# Altium schematic sheet 24V Multiphase Buck.SchDoc
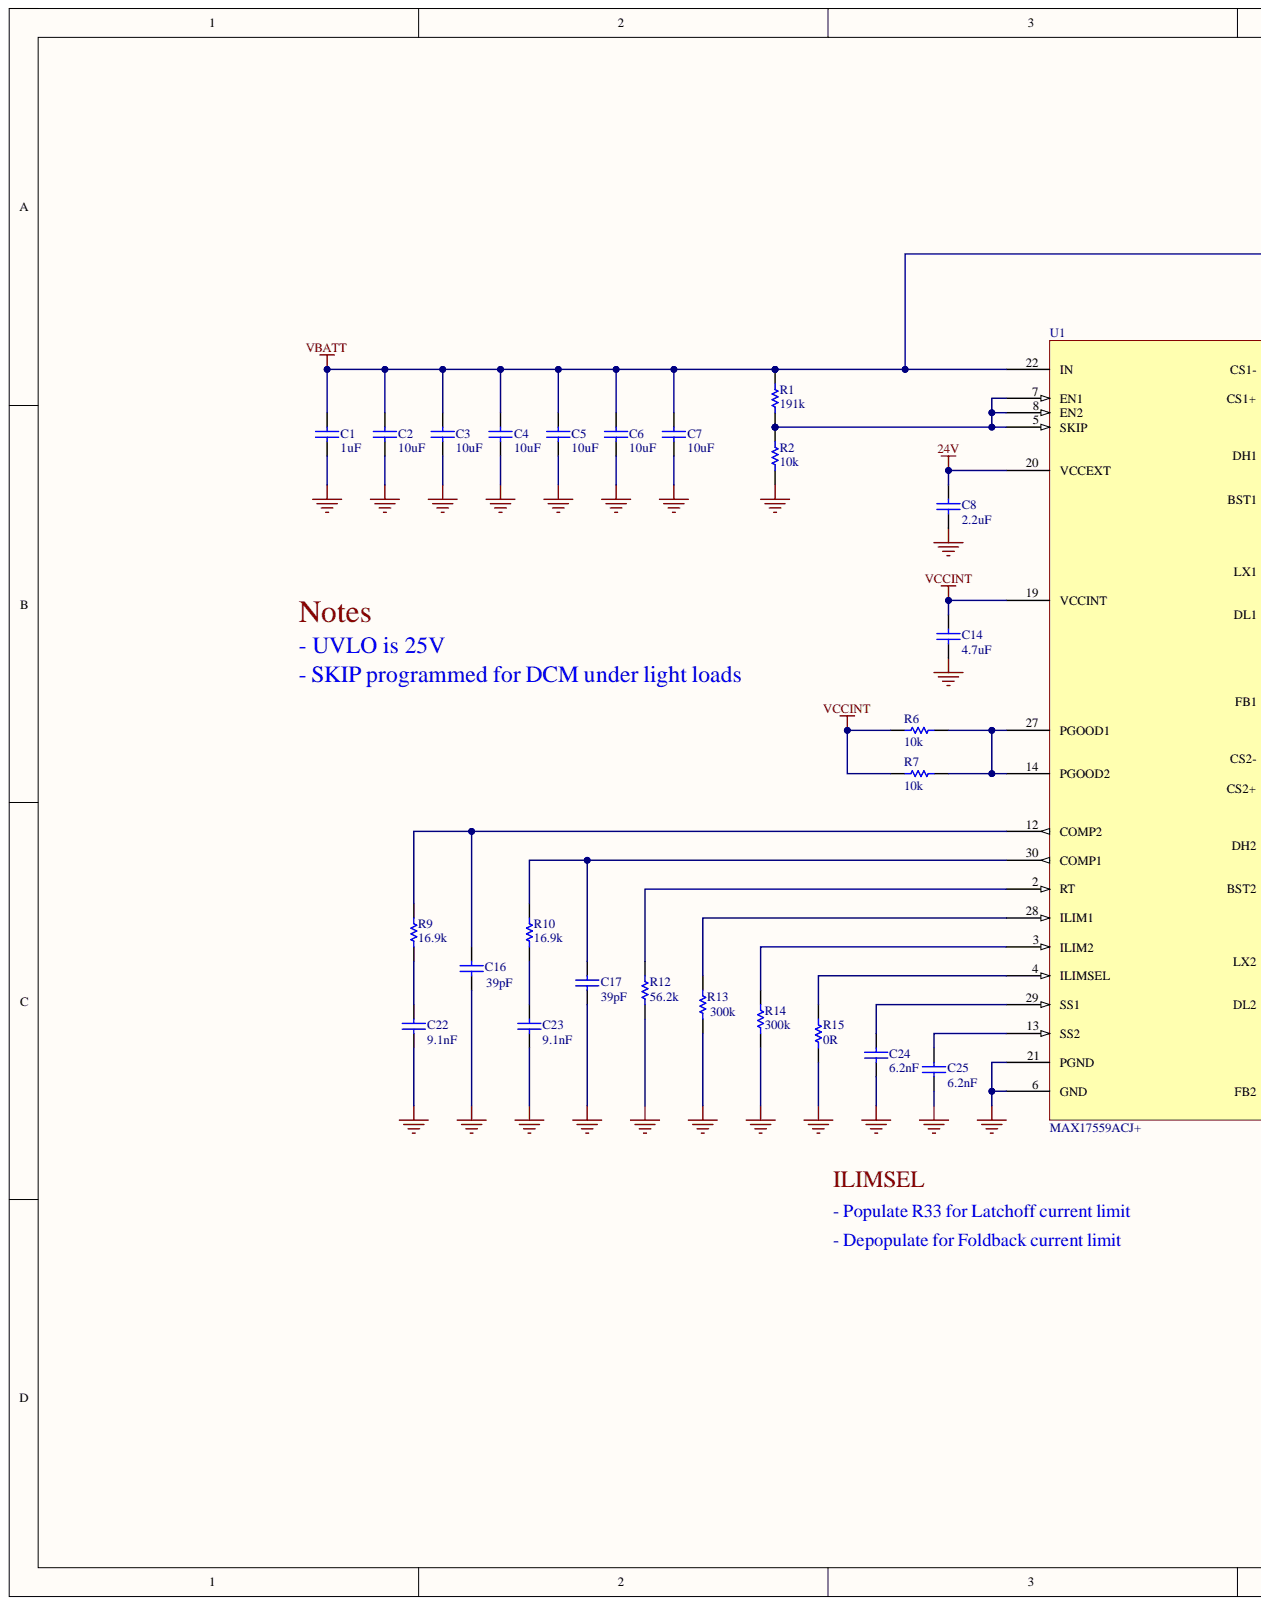
[diagram p0: 24V Multiphase Buck.SchDoc, left]
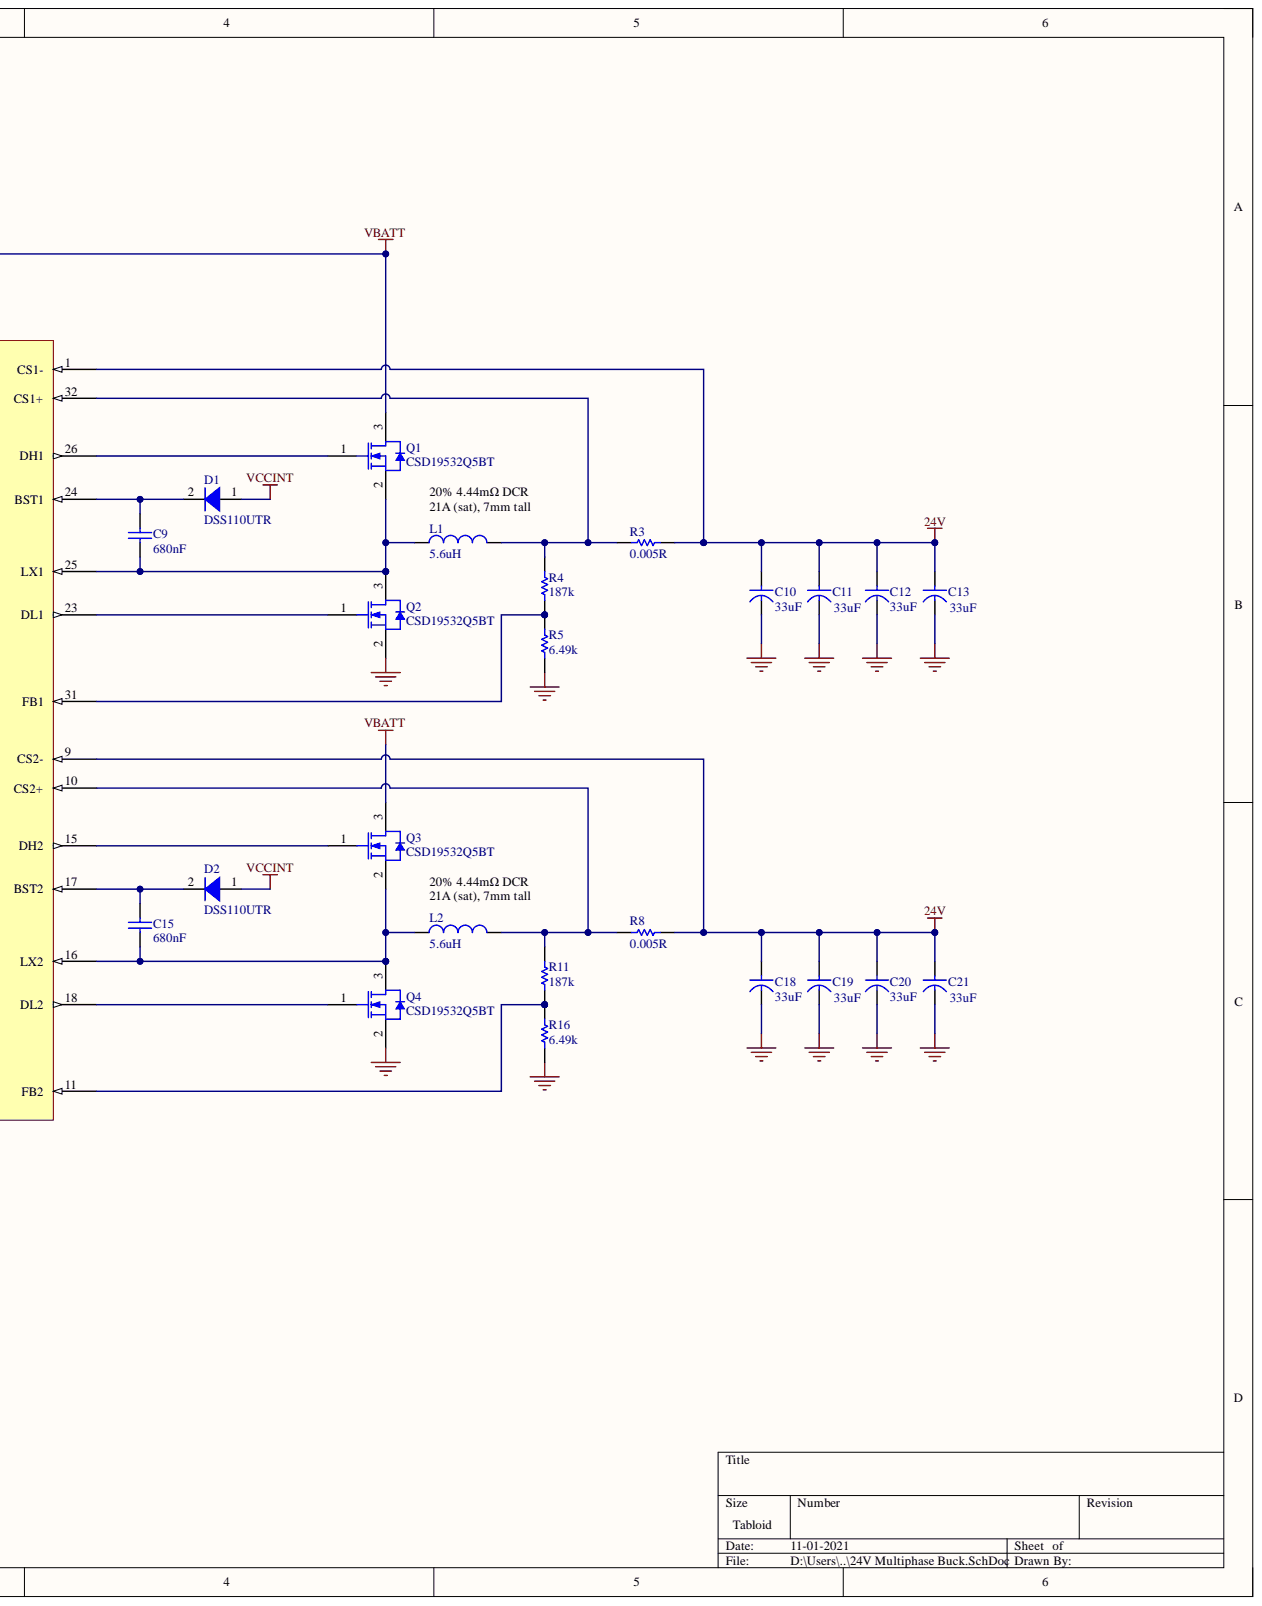
[diagram p1: 24V Multiphase Buck.SchDoc, right]

## Parts
C1  1uF  [C1206]
C2  10uF  [C2220]
C3  10uF  [C2220]
C4  10uF  [C2220]
C5  10uF  [C2220]
C6  10uF  [C2220]
C7  10uF  [C2220]
C8  2.2uF  [C0603]
C9  680nF  [C1206]
C10  33uF  [CAPAE9983X105M]
C11  33uF  [CAPAE9983X105M]
C12  33uF  [CAPAE9983X105M]
C13  33uF  [CAPAE9983X105M]
C14  4.7uF  [C0805]
C15  680nF  [C1206]
C16  39pF  [C0805]
C17  39pF  [C0805]
C18  33uF  [CAPAE9983X105M]
C19  33uF  [CAPAE9983X105M]
C20  33uF  [CAPAE9983X105M]
C21  33uF  [CAPAE9983X105M]
C22  9.1nF  [C0603]
C23  9.1nF  [C0603]
C24  6.2nF  [C0603]
C25  6.2nF  [C0603]
D1  DSS110UTR  [SODFL360X110-2N]
D2  DSS110UTR  [SODFL360X110-2N]
L1  5.6uH  Height: 7mm  Inductance Tolerance: 20%  Max DC Current: 21A  [IHLP6767GZER5R6M11]
L2  5.6uH  Height: 7mm  Inductance Tolerance: 20%  Max DC Current: 21A  [IHLP6767GZER5R6M11]
Q1  CSD19532Q5BT  [CSD19532Q5BT]
Q2  CSD19532Q5BT  [CSD19532Q5BT]
Q3  CSD19532Q5BT  [CSD19532Q5BT]
Q4  CSD19532Q5BT  [CSD19532Q5BT]
R1  191k  [RESC1005X04N]
R2  10k  [RESC1005X04N]
R3  0.005R
R4  187k  [RESC1005X04N]
R5  6.49k  [RESC1005X04N]
R6  10k  [RESC1005X04N]
R7  10k  [RESC1005X04N]
R8  0.005R
R9  16.9k  [R0805]
R10  16.9k  [R0805]
R11  187k  [RESC1005X04N]
R12  56.2k  [RESC1005X04N]
R13  300k  [RESC1005X04N]
R14  300k  [RESC1005X04N]
R15  0R  [RESC1005X04N]
R16  6.49k  [RESC1005X04N]
U1  MAX17559ACJ+

## Nets
24V: C8.2 C10.1 C11.1 C12.1 C13.1 C18.1 C19.1 C20.1 C21.1 R3.2 R8.2 U1.1(CS1-) U1.9(CS2-) U1.20(VCCEXT)
GND: C1.1 C2.1 C3.1 C4.1 C5.1 C6.1 C7.1 C8.1 C10.2 C11.2 C12.2 C13.2 C14.1 C16.1 C17.1 C18.2 C19.2 C20.2 C21.2 C22.1 C23.1 C24.1 C25.1 Q2.2 Q4.2 R2.1 R5.1 R12.1 R13.1 R14.1 R15.1 R16.1 U1.6(GND) U1.21(PGND)
VBATT: C1.2 C2.2 C3.2 C4.2 C5.2 C6.2 C7.2 Q1.3 Q3.3 R1.2 U1.22(IN)
VCCINT: C14.2 D1.1 D2.1 R6.1 R7.1 U1.19(VCCINT)
NetC9_1: C9.1 L1.1 Q1.2 Q2.3 U1.25(LX1)
NetC9_2: C9.2 D1.2 U1.24(BST1)
NetC15_1: C15.1 L2.1 Q3.2 Q4.3 U1.16(LX2)
NetC15_2: C15.2 D2.2 U1.17(BST2)
NetC16_2: C16.2 R9.2 U1.12(COMP2)
NetC17_2: C17.2 R10.2 U1.30(COMP1)
NetC22_2: C22.2 R9.1
NetC23_2: C23.2 R10.1
NetC24_2: C24.2 U1.29(SS1)
NetC25_2: C25.2 U1.13(SS2)
NetL1_2: L1.2 R3.1 R4.2 U1.32(CS1+)
NetL2_2: L2.2 R8.1 R11.2 U1.10(CS2+)
NetQ1_1: Q1.1 U1.26(DH1)
NetQ2_1: Q2.1 U1.23(DL1)
NetQ3_1: Q3.1 U1.15(DH2)
NetQ4_1: Q4.1 U1.18(DL2)
NetR1_1: R1.1 R2.2 U1.5(SKIP) U1.7(EN1) U1.8(EN2)
NetR4_1: R4.1 R5.2 U1.31(FB1)
NetR6_2: R6.2 R7.2 U1.14(PGOOD2) U1.27(PGOOD1)
NetR11_1: R11.1 R16.2 U1.11(FB2)
NetR12_2: R12.2 U1.2(RT)
NetR13_2: R13.2 U1.28(ILIM1)
NetR14_2: R14.2 U1.3(ILIM2)
NetR15_2: R15.2 U1.4(ILIMSEL)

## Library data of the parts
C1 = CL31B105KCHNFNE: Cap Ceramic 1uF 100V X7R 10% SMD 1206 125°C Embossed Plastic T/R; Manufacturer: Samsung; Database Table Name: Capacitor; Capacitance: 1uF; Case Code (Imperial): 1206; Case Code (Metric): 3216; Case/Package: 1206; Category: Ceramic Capacitors; Component Kind: Standard; ComponentLink1URL: https://datasheet.ciiva.com/25793/cl31b105kchnfne-25793297.pdf?src-supplier=Digi-Key; Length: 0.126inch; Manufacturer Part Number: CL31B105KCHNFNE; Max Operating Temperature: 125°C; Min Operating Temperature: -55°C; Mount: Surface Mount; Package Quantity: 1; Packaging: Cut Tape; Part Number: CL31B105KCHNFNE; Pricing 1: 1=0.27000, 10=0.18500, 50=0.12360, 100=0.10510, 500=0.07418, 1000=0.06492 (USD); RoHS: Yes; RoHS Compliant: Yes; Stock 1: 65421; Supplier 1: Digi-Key; Supplier Part Number 1: 1276-3094-1-ND; Thickness: 0.071inch; Tolerance: 10%; Voltage Rating: 100V; Width: 0.063inch; Library Name: EMRLibrary.DBLib
C2-C7 = 22201C106MAT2A: Cap Ceramic 10uF 100V X7R 20% SMD 2220 125°C Embossed T/R; Manufacturer: Kyocera AVX; Database Table Name: Capacitor; Capacitance: 10uF; Case Code (Imperial): 2220; Case Code (Metric): 5750; Case/Package: 2220; Category: Ceramic Capacitors; Component Kind: Standard; Dielectric: X7R; Length: 0.224inch; Manufacturer Part Number: 22201C106MAT2A; Max Operating Temperature: 125°C; Min Operating Temperature: -55°C; Mount: Surface Mount; Packaging: Tape and Reel; Part Number: 22201C106MAT2A; Pricing 1: 1=2.06000, 10=1.66400, 50=1.45200, 100=1.27050 (USD); RoHS: Yes; Stock 1: 8969; Supplier 1: Digi-Key; Supplier Part Number 1: 478-9622-1-ND; Thickness: 0.11inch; Tolerance: 20%; Voltage Rating: 100V; Voltage Rating (DC): 100V; Width: 0.197inch; Library Name: EMRLibrary.DBLib
C8 = GRT188R61H225KE13D: 0603 2.2 uF 50 V ±10 % Tolerance X5R SMT Multilayer Ceramic Capacitor; Manufacturer: Murata; Tolerance: 10%; Voltage Rating: 50V; Capacitance: 2.2uF; Case Code (Imperial): 0603; Case Code (Metric): 1608; Case/Package: 0603; Category: Ceramic Capacitors; Component Kind: Standard; Database Table Name: Capacitor; Dielectric: X5R; Manufacturer Part Number: GRT188R61H225KE13D; Max Operating Temperature: 85°C; Min Operating Temperature: -55°C; Mount: Surface Mount; Packaging: Tape and Reel; Part Number: GRT188R61H225KE13D; Pricing 1: 1=0.33000, 10=0.22900, 50=0.16000, 100=0.13720, 500=0.10292, 1000=0.08690 (USD); RoHS: Yes; Stock 1: 38519; Supplier 1: Digi-Key; Supplier Part Number 1: 490-12332-1-ND; Library Name: EMRLibrary.DBLib
C9 C15 = C1206C684K1RAC7800: Cap Cer 0.68UF 100V X7R 1206; Manufacturer: KEMET; Database Table Name: Capacitor; Capacitance: 680nF; Case/Package: 1206; Category: Ceramic Capacitors; Component Kind: Standard; Manufacturer Part Number: C1206C684K1RAC7800; Max Operating Temperature: 100°C; Min Operating Temperature: 0°C; Mount: Surface Mount; Part Number: C1206C684K1RAC7800; Pricing 1: 1=0.38000, 10=0.26000, 50=0.18240, 100=0.15630, 500=0.11722, 1000=0.09898 (USD); RoHS: No; Stock 1: 7801; Supplier 1: Digi-Key; Supplier Part Number 1: 399-15137-1-ND; Library Name: EMRLibrary.DBLib
C10-C13 C18-C21 = EEV-FC1H330P: Cap 33UF 50V Elect Fc SMD; Manufacturer: Panasonic; Database Table Name: Capacitor; Ripple Current: 225mA; Tolerance: 20%; Capacitance: 33uF; Case/Package: Radial; Category: Aluminum Electrolytic Capacitors; Component Kind: Standard; Manufacturer Part Number: EEV-FC1H330P; Max Operating Temperature: 105°C; Min Operating Temperature: -40°C; Mount: Surface Mount; Package Quantity: 500; Packaging: Tape and Reel; Part Number: EEV-FC1H330P; Pricing 1: 1=0.69000, 10=0.42200, 50=0.30300, 100=0.26200 (USD); RoHS: No; Stock 1: 2130; Supplier 1: Digi-Key; Supplier Part Number 1: PCE3258CT-ND; Termination: SMD/SMT; Voltage Rating: 50V; Library Name: EMRLibrary.DBLib
C14 = CL21A475KOFNNNG: 4.7µF Ceramic Capacitor 16V % Samsung Electro-Mechanics CL21A475KOFNNNG; Dielectric: X5R; Tolerance: 10%; Capacitance: 4.7uF; Case Code (Imperial): 0805; Case Code (Metric): 2012; Case/Package: 0805; Component Kind: Standard; Database Table Name: Capacitor; Depth: 1.25mm; Height: 1.25mm; Length: 2mm; Manufacturer: Samsung; Manufacturer Part Number: CL21A475KOFNNNG; Max Operating Temperature: 85°C; Min Operating Temperature: -55°C; Packaging: Tape and Reel; Part Number: CL21A475KOFNNNG; Pricing 1: 1=0.12, 10=0.084, 100=0.0394, 500=0.0276, 1000=0.0229 (USD); Radiation Hardening: No; Stock 1: 16459; Supplier 1: Digi-Key; Supplier Part Number 1: 1276-6463-6-ND; Thickness: 0.053inch; Voltage Rating: 16V; Width: 0.049inch; Library Name: EMRLibrary.DBLib
C16 C17 = CL21C390JBANNNC: Ceramic capacitor SMD-0805 39pF /50V NP0# J +-5% Samsung , RoHS; Capacitance: 39pF; Case Code (Imperial): 0805; Case Code (Metric): 2012; Case/Package: 0805; Category: Ceramic Capacitors; Component Kind: Standard; Database Table Name: Capacitor; Manufacturer: Samsung; Manufacturer Part Number: CL21C390JBANNNC; Mount: Surface Mount; Part Number: CL21C390JBANNNC; Pricing 1: 1=0.10000, 10=0.05100, 50=0.02780, 100=0.02270, 500=0.01622, 1000=0.01274 (USD); RoHS: Yes; Stock 1: 206197; Supplier 1: Digi-Key; Supplier Part Number 1: 1276-1769-1-ND; Library Name: EMRLibrary.DBLib
C22 C23 = C0603C912J3GACTU: Cap Ceramic 0.0091uF 25V C0G 5% Pad SMD 0603 125°C Extreme Low ESR T/R; Manufacturer: KEMET; Database Table Name: Capacitor; Capacitance: 9.1nF; Case Code (Imperial): 0603; Case Code (Metric): 1608; Case/Package: 0603; Category: Ceramic Capacitors; Component Kind: Standard; Dielectric: C0G; Dielectric Material: Ceramic; Manufacturer Part Number: C0603C912J3GACTU; Max Operating Temperature: 125°C; Min Operating Temperature: -55°C; Mount: Surface Mount; Number of Pins: 2; Package Quantity: 1; Packaging: Tape and Reel; Part Number: C0603C912J3GACTU; Pricing 1: 1=0.54000, 10=0.37300, 50=0.26120, 100=0.22390, 500=0.16792, 1000=0.14181 (USD); RoHS: Yes; Stock 1: 17785; Supplier 1: Digi-Key; Supplier Part Number 1: 399-9982-1-ND; Termination: SMD/SMT; Tolerance: 5%; Voltage Rating: 25V; Voltage Rating (DC): 25V; Library Name: EMRLibrary.DBLib
C24 C25 = 06031C622JAT2A: Cap MLCC SMD 0603 100V X7R 6200pF ±5% Commercial Ti/Sn Plated 7T/R"; Manufacturer: Kyocera AVX; Database Table Name: Capacitor; Capacitance: 6.2nF; Case/Package: 0603; Category: Ceramic Capacitors; Component Kind: Standard; Manufacturer Part Number: 06031C622JAT2A; Mount: Surface Mount; Part Number: 06031C622JAT2A; Pricing 1: 1=0.27000, 10=0.18600, 50=0.12420, 100=0.10560, 500=0.07452, 1000=0.06520 (USD); RoHS: Yes; Stock 1: 53066; Supplier 1: Digi-Key; Supplier Part Number 1: 478-10228-1-ND; Library Name: EMRLibrary.DBLib
D1 D2 = DSS110UTR: Schottky 100V SOD-123FL; Manufacturer: SMC; Database Table Name: Diode; Category: Industrial Control; Component Kind: Standard; Manufacturer Part Number: DSS110UTR; Mount: Surface Mount; Packaging: Tape and Reel; Part Number: DSS110UTR; Pricing 1: 3000=0.03080, 9000=0.02570, 15000=0.02550, 60000=0.02480, 90000=0.02460 (USD); RoHS: No; Supplier 1: Future Electronics; Supplier Part Number 1: 6125592; Library Name: EMRLibrary.DBLib
L1 L2 = IHLP6767GZER5R6M11: Ind High Current Shielded Wirewound 5.6uH 20% 100KHz 28A 6767 T/R; Manufacturer: Vishay Dale; Core Material: Metal,Composite; Database Table Name: Inductor; DC Resistance (DCR): 4.44mR; Self Resonant Frequency: 11.8MHz; Case Code (Imperial): 1210; Case Code (Metric): 3225; Case/Package: 6767; Category: Fixed Inductors; Component Kind: Standard; Component Type: Inductor; ComponentLink1Description: https://datasheet.ciiva.com/1052/lp67gz11-1052676.pdf?src-supplier=Digi-Key; ComponentLink1URL: https://datasheet.ciiva.com/1052/lp67gz11-1052676.pdf?src-supplier=Digi-Key; ComponentLink2Description: https://datasheet.ciiva.com/1052/lp67gz11-1052677.pdf?src-supplier=Digi-Key; ComponentLink2URL: https://datasheet.ciiva.com/1052/lp67gz11-1052677.pdf?src-supplier=Digi-Key; ComponentLink3Description: https://datasheet.ciiva.com/1052/lp67gz11-1052678.pdf?src-supplier=Digi-Key; ComponentLink3URL: https://datasheet.ciiva.com/1052/lp67gz11-1052678.pdf?src-supplier=Digi-Key; ComponentLink4Description: https://datasheet.ciiva.com/8496/lp67gz11-8496089.pdf?src-supplier=Avnet; ComponentLink4URL: https://datasheet.ciiva.com/8496/lp67gz11-8496089.pdf?src-supplier=Avnet; ComponentLink5Description: https://datasheet.ciiva.com/8017/1852632-8017494.pdf?src-supplier=Element14; ComponentLink5URL: https://datasheet.ciiva.com/8017/1852632-8017494.pdf?src-supplier=Element14; ComponentLink6Description: https://datasheet.ciiva.com/17269/lp67gz11-17269071.pdf?src-supplier=Avnet+Europe; ComponentLink6URL: https://datasheet.ciiva.com/17269/lp67gz11-17269071.pdf?src-supplier=Avnet+Europe; Composition: Wirewound; Contact Plating: Tin; Current Rating: 28A; Depth: 17.15mm; Height - Seated (Max): 0.276inch; Inductance: 5.6uH; Lead Free: Lead Free; Length: 17.28mm; Manufacturer Part Number: IHLP6767GZER5R6M11; Max Operating Temperature: 125°C; Military Standard: Not; Min Operating Temperature: -55°C; Mount: Surface Mount; Number of Pins: 2; Package Quantity: 200; Packaging: Tape and Reel; Part Number: IHLP6767GZER5R6M11; Pricing 1: 1=4.62000, 10=4.23500, 25=3.85000, 50=3.23400, 100=2.77200 (USD); Radiation Hardening: No; REACH SVHC: Unknown; RMS Current (Irms): 28A; RoHS: Yes; RoHS Compliant: Yes; Saturation Current: 21A; Series: IHLP-6767GZ-11; Shielding: Shielded; Stock 1: 4408; Supplier 1: Digi-Key; Supplier Part Number 1: 541-1283-1-ND; Termination: SMD/SMT; Test Frequency: 100kHz; Tolerance: 20%; Weight: 0.000564oz; Width: 0.675inch; Library Name: EMRLibrary.DBLib
Q1-Q4 = CSD19532Q5BT: MOSFET N-CH 100V 100A VSON; Manufacturer: Texas Instruments; Database Table Name: Transistor; Drain to Source Breakdown Voltage: 50V; Drain to Source Resistance: 3.5R; Element Configuration: Single; Fall Time: 5.1ns; Gate to Source Voltage (Vgs): 20V; Input Capacitance: 4.81nF; Max Power Dissipation: 3.1W; Number of Channels: 1; Rds On Max: 4.9mR; Rise Time: 1.8ns; Threshold Voltage: 1.25V; Turn-Off Delay Time: 5.3ns; Turn-On Delay Time: 2.2ns; Case/Package: SOT-23; Category: Integrated Circuits (ICs); Component Kind: Standard; ComponentLink1Description: http://www.ti.com/lit/ds/symlink/csd19532q5b.pdf?src-supplier=Digi-Key; ComponentLink1URL: http://www.ti.com/lit/ds/symlink/csd19532q5b.pdf?src-supplier=Digi-Key; Continuous Drain Current (ID): 100A; Drain to Source Voltage (Vdss): 100V; Lead Free: Contains Lead; Manufacturer Part Number: CSD19532Q5BT; Max Operating Temperature: 150°C; Min Operating Temperature: -55°C; Mount: Surface Mount; Number of Pins: 8; Package Quantity: 3000; Packaging: Tape and Reel; Part Number: CSD19532Q5BT; Pricing: 1=0.56000, 10=0.48300, 25=0.45040, 100=0.33460, 500=0.26126, 1000=0.21236 (USD); Pricing 1: 1=3.21000, 10=2.88000, 100=2.31490 (USD); REACH SVHC: No SVHC; RoHS: Yes; RoHS Compliant: Yes; Stock: 8238; Supplier 1: Digi-Key; Supplier Part Number 1: 296-44471-6-ND; Weight: 0.03g; Library Name: EMRLibrary.DBLib
R1 = RC0402FR-07191KL: Res Thick Film 0402 191K Ohm 1% 1/16W 100ppm/C Molded SMD Paper T/R; Manufacturer: Yageo; Database Table Name: Resistor; Power Rating: 62.5mW; Voltage Rating: 50V; Case Code (Imperial): 0402; Case Code (Metric): 1005; Case/Package: 0402; Category: Chip SMD Resistors; Component Kind: Standard; Contact Plating: Tin,Lead; Manufacturer Part Number: RC0402FR-07191KL; Max Operating Temperature: 155°C; Min Operating Temperature: -55°C; Packaging: Tape and Reel; Part Number: RC0402FR-07191KL; Pricing 1: 1=0.10000, 10=0.01600, 100=0.00660, 1000=0.00299, 2500=0.00259, 5000=0.00214 (USD); Resistance: 191k; Resistor Type: Thick Film; RoHS: Yes; Stock 1: 393401; Supplier 1: Digi-Key; Supplier Part Number 1: YAG3022CT-ND; Tolerance: 1%; Library Name: EMRLibrary.DBLib
R2 R6 R7 = RC0402FR-0710KL: RES SMD 10K OHM 1% 1/16W 0402; Manufacturer: Yageo; Database Table Name: Resistor; Power Rating: 62.5mW; Temperature Coefficient: 100ppm/°C; Voltage Rating: 50V; Case Code (Imperial): 0402; Case Code (Metric): 1005; Case/Package: 0402; Component Kind: Standard; Composition: Thick Film; Depth: 0.5mm; Height: 0.32mm; Lead Free: Lead Free; Lead Pitch: 0.2mm; Length: 1mm; Manufacturer Part Number: RC0402FR-0710KL; Max Operating Temperature: 155°C; Military Standard: Not; Min Operating Temperature: -55°C; Packaging: Tape and Reel; Part Number: RC0402FR-0710KL; Pricing 1: 1=0.1, 10=0.014, 100=0.0056, 1000=0.0025, 2500=0.0022, 5000=0.0018 (USD); REACH SVHC: No SVHC; Resistance: 10k; Stock 1: 14034353; Supplier 1: Digi-Key; Supplier Part Number 1: 311-10.0KLRCT-ND; Tolerance: 1%; Value: 10k; Width: 0.5mm; Library Name: EMRLibrary.DBLib
  R6 R7: ComponentLink1Description: https://datasheet.ciiva.com/7660/38568-7660787.pdf; ComponentLink1URL: https://datasheet.ciiva.com/7660/38568-7660787.pdf; ComponentLink2Description: https://datasheet.ciiva.com/7849/1784430-7849813.pdf; ComponentLink2URL: https://datasheet.ciiva.com/7849/1784430-7849813.pdf; ComponentLink3Description: https://datasheet.ciiva.com/11808/0900766b80c66297-11808309.pdf; ComponentLink3URL: https://datasheet.ciiva.com/11808/0900766b80c66297-11808309.pdf; ComponentLink4Description: https://datasheet.ciiva.com/16744/pyu-rc0402-51-rohs-l-6-r-16744005.pdf; ComponentLink4URL: https://datasheet.ciiva.com/16744/pyu-rc0402-51-rohs-l-6-r-16744005.pdf; ComponentLink5Description: https://datasheet.ciiva.com/7849/1686128-7849814.pdf; ComponentLink5URL: https://datasheet.ciiva.com/7849/1686128-7849814.pdf; ComponentLink6Description: https://datasheet.ciiva.com/7849/1784430-7849815.pdf; ComponentLink6URL: https://datasheet.ciiva.com/7849/1784430-7849815.pdf; ComponentLink7Description: https://datasheet.ciiva.com/22747/1018594-22747512.pdf; ComponentLink7URL: https://datasheet.ciiva.com/22747/1018594-22747512.pdf; ComponentLink8Description: https://datasheet.ciiva.com/12667/pyu-rc0402-51-rohs-l-6-r-12667067.pdf; ComponentLink8URL: https://datasheet.ciiva.com/12667/pyu-rc0402-51-rohs-l-6-r-12667067.pdf; ComponentLink9Description: https://datasheet.ciiva.com/7983/1018594-7983486.pdf; ComponentLink9URL: https://datasheet.ciiva.com/7983/1018594-7983486.pdf
R3 R8 = CSSH2728FT5L00: Res Metal Alloy 2728 0.005 Ohm 1% 4W ±25ppm/°C Molded SMD SMD Plastic T/R; Manufacturer: Stackpole Electronics; Database Table Name: Resistor; Case Code (Imperial): 2827; Case Code (Metric): 7067; Case/Package: 2728; Category: Chip SMD Resistors; Component Kind: Standard; Contact Plating: Tin,Matte; Height: 0.049inch; Length: 0.264inch; Manufacturer Part Number: CSSH2728FT5L00; Max Operating Temperature: 225°C; Max Power Dissipation: 4W; Min Operating Temperature: -55°C; Mount: Surface Mount; Number of Terminations: 2; Packaging: Tape and Reel; Part Number: CSSH2728FT5L00; Power Rating: 4W; Pricing 1: 1=1.08000, 10=0.96100, 25=0.88360, 50=0.80600, 100=0.69750, 250=0.58900, 500=0.49600 (USD); Resistance: 0.005R; Resistor Type: Metal Foil,Metal Alloy; RoHS: Yes; Series: CSSH; Stock 1: 21187; Supplier 1: Digi-Key; Supplier Part Number 1: CSSH2728FT5L00CT-ND; Temperature Coefficient: 25ppm/°C; Tolerance: 1%; Width: 0.283inch; Library Name: EMRLibrary.DBLib
R4 R11 = RC0402FR-07187KL: Res Thick Film 1.0 x 0.5 mm 187K Ohm 1% 0.063W(1/16W) 100ppm/ C Molded SMD Paper T/R; Manufacturer: Yageo; Database Table Name: Resistor; Power Rating: 62.5mW; Temperature Coefficient: 100ppm/°C; Voltage Rating: 50V; Case Code (Imperial): 0402; Case Code (Metric): 1005; Case/Package: 0402; Category: Chip SMD Resistors; Component Kind: Standard; Contact Plating: Tin,Lead,Matte; Manufacturer Part Number: RC0402FR-07187KL; Max Operating Temperature: 155°C; Min Operating Temperature: -55°C; Number of Terminations: 2; Package Quantity: 1; Packaging: Tape and Reel; Part Number: RC0402FR-07187KL; Pricing 1: 1=0.10000, 10=0.01600, 100=0.00660, 1000=0.00299, 2500=0.00259, 5000=0.00214 (USD); Resistance: 187k; Resistor Type: Thick Film; RoHS: Yes; Stock 1: 381945; Supplier 1: Digi-Key; Supplier Part Number 1: 311-187KLRCT-ND; Termination: SMD/SMT; Tolerance: 1%; Library Name: EMRLibrary.DBLib
R5 R16 = AC0402FR-076K49L: Chip Resistor Automotive Grade Thick Film 0402 6.49kOhm 1% Paper T/R; Manufacturer: Yageo; Max Power Dissipation: 63mW; Power Rating: 62.5mW; Temperature Coefficient: 100ppm/°C; Voltage Rating: 50V; Case Code (Imperial): 0402; Case Code (Metric): 1005; Case/Package: 0402; Category: Chip SMD Resistors; Component Kind: Standard; Database Table Name: Resistor; Manufacturer Part Number: AC0402FR-076K49L; Max Operating Temperature: 155°C; Min Operating Temperature: -55°C; Number of Terminations: 2; Packaging: Tape and Reel; Part Number: AC0402FR-076K49L; Pricing 1: 1=0.10000, 10=0.02200, 100=0.00900, 1000=0.00402, 2500=0.00349, 5000=0.00288 (USD); Resistance: 6.49k; Resistor Type: Thick Film; RoHS: Yes; Stock 1: 7388; Supplier 1: Digi-Key; Supplier Part Number 1: 13-AC0402FR-076K49LCT-ND; Termination: SMD/SMT; Tolerance: 1%; Library Name: EMRLibrary.DBLib
R9 R10 = RC0805FR-0716K9L: Res Thick Film 0805 16.9K Ohm 1% 1/8W 100ppm/C Molded SMD SMD Paper T/R; Manufacturer: Yageo; Database Table Name: Resistor; Max Power Dissipation: 125mW; Temperature Coefficient: 100ppm/°C; Voltage Rating (DC): 150V; Case Code (Imperial): 0805; Case Code (Metric): 2012; Case/Package: 0805; Category: Chip SMD Resistors; Component Kind: Standard; Contact Plating: Tin,Lead,Matte; Features: Moisture Resistant; Manufacturer Part Number: RC0805FR-0716K9L; Max Operating Temperature: 155°C; Min Operating Temperature: -55°C; Number of Terminations: 2; Package Quantity: 1; Packaging: Tape and Reel; Part Number: RC0805FR-0716K9L; Power Rating: 125mW; Pricing 1: 1=0.10000, 10=0.04200, 100=0.01690, 1000=0.00759, 2500=0.00659 (USD); Resistance: 16.9k; Resistor Type: Thick Film; RoHS: Yes; Size Code: 0805; Stock 1: 4730; Supplier 1: Digi-Key; Supplier Part Number 1: 311-16.9KCRCT-ND; Tolerance: 1%; Library Name: EMRLibrary.DBLib
R12 = RC0402FR-0756K2L: Res General Purpose Thick Film 0402 56.2K Ohm 1% 1/16W ±100ppm/°C Molded SMD Paper T/R; Manufacturer: Yageo; Database Table Name: Resistor; Max Power Dissipation: 63mW; Power Rating: 62.5mW; Voltage Rating: 50V; Case Code (Imperial): 0402; Case Code (Metric): 1005; Case/Package: 0402; Category: Chip SMD Resistors; Component Kind: Standard; Manufacturer Part Number: RC0402FR-0756K2L; Max Operating Temperature: 155°C; Min Operating Temperature: -55°C; Number of Terminations: 2; Packaging: Tape and Reel; Part Number: RC0402FR-0756K2L; Pricing 1: 1=0.10000, 10=0.01600, 100=0.00660, 1000=0.00299, 2500=0.00259, 5000=0.00214 (USD); Resistance: 56.2k; Resistor Type: Thick Film; RoHS: Yes; Size Code: 0402; Stock 1: 6256; Supplier 1: Digi-Key; Supplier Part Number 1: YAG3187CT-ND; Temperature Coefficient: 100ppm/°C; Termination: SMD/SMT; Tolerance: 1%; Library Name: EMRLibrary.DBLib
R13 R14 = RC0402FR-07300KL: YAGEO - RC0402FR-07300KL - SMD Chip Resistor, 0402 [1005 Metric], 300 kohm, RC Series, 50 V, Thick Film, 63 mW; Power Rating: 63mW; Tolerance: 1%; Voltage Rating: 50V; Case Code (Imperial): 0402; Case Code (Metric): 1005; Case/Package: 0402; Category: Chip SMD Resistors; Component Kind: Standard; Database Table Name: Resistor; Manufacturer: Yageo; Manufacturer Part Number: RC0402FR-07300KL; Max Operating Temperature: 155°C; Min Operating Temperature: -55°C; Packaging: Tape and Reel; Part Number: RC0402FR-07300KL; Pricing 1: 10000=0.00300 (GBP); Resistance: 300k; RoHS: Yes; Size Code: 0402; Stock 1: 5400; Supplier 1: RSComponents; Supplier Part Number 1: 1987744; Library Name: EMRLibrary.DBLib
R15 = RC0402FR-070RL: Thick Film Resistors - SMD 0.0ohm 1%; Manufacturer: Yageo; Power Rating: 62.5mW; Temperature Coefficient: 200ppm/°C; Voltage Rating: 50V; Case Code (Imperial): 0402; Case Code (Metric): 1005; Case/Package: 0402; Component Kind: Standard; Composition: Thick Film; Database Table Name: Resistor; Height: 0.35mm; Lead Free: Lead Free; Length: 1mm; Manufacturer Part Number: RC0402FR-070RL; Max Operating Temperature: 155°C; Min Operating Temperature: -55°C; Packaging: Tape and Reel; Part Number: RC0402FR-070RL; Pricing 1: 1=0.1, 10=0.012, 25=0.0084, 50=0.0064, 100=0.0048, 250=0.0036, 500=0.0029, 1000=0.0022, 5000=0.0015 (USD); Resistance: 0R; Stock 1: 1711107; Supplier 1: Digi-Key; Supplier Part Number 1: 311-0.0LRCT-ND; Tolerance: 1%; Value: 0R; Width: 0.5mm; Library Name: EMRLibrary.DBLib
U1 = MAX17559ACJ+: Buck Regulator Positive Output Step-Down - DC-DC Controller IC 32-TQFP (7x7); Component Kind: Standard; Library Name: EMR.SchLib
